# Altium schematic sheet B-MCU.SchDoc(B-MCU)
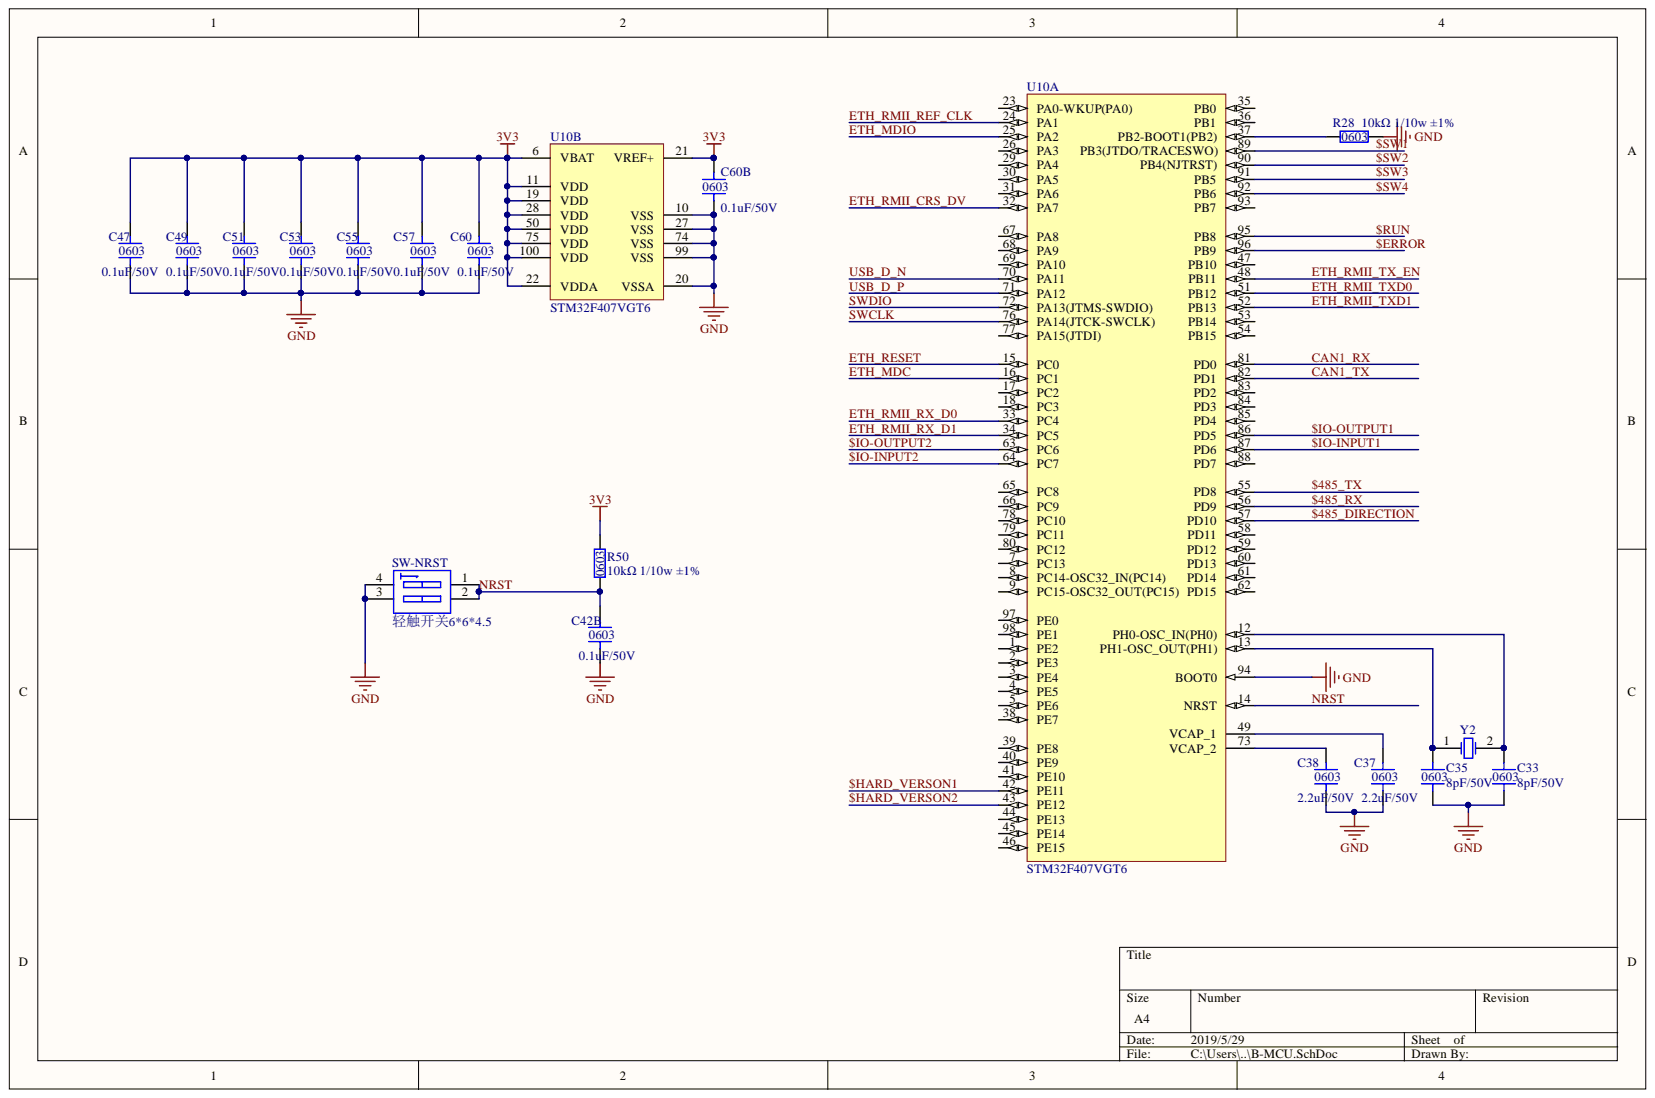
[diagram p0: B-MCU.SchDoc]

## Parts
C33  8pF/50V  [C-0603]
C35  8pF/50V  [C-0603]
C37  2.2uF/50V  [C-0603]
C38  2.2uF/50V  [C-0603]
C42B  0.1uF/50V  [C-0603]
C47  0.1uF/50V  [C-0603]
C49  0.1uF/50V  [C-0603]
C51  0.1uF/50V  [C-0603]
C53  0.1uF/50V  [C-0603]
C55  0.1uF/50V  [C-0603]
C57  0.1uF/50V  [C-0603]
C60  0.1uF/50V  [C-0603]
C60B  0.1uF/50V  [C-0603]
R28  10kΩ 1/10w ±1%  [R-0603]
R50  10kΩ 1/10w ±1%  [R-0603]
SW-NRST  轻触开关6*6*4.5  [轻触开关6*6*4.5]
U10  STM32F407VGT6  [STM-LQFP100_N]  (parts A,B)
Y2  FA-238 EPSON 3225 8PF 20ppm 25M  [3225XTAL]  (value not drawn)

## Nets
3V3: C47.2 C49.2 C51.2 C53.2 C55.2 C57.2 C60.2 C60B.2 R50.2 U10.6(VBAT) U10.11(VDD) U10.19(VDD) U10.21(VREF+) U10.22(VDDA) U10.28(VDD) U10.50(VDD) U10.75(VDD) U10.100(VDD)
GND: C33.1 C35.1 C37.1 C38.1 C42B.1 C47.1 C49.1 C51.1 C53.1 C55.1 C57.1 C60.1 C60B.1 R28.2 SW-NRST.3 SW-NRST.4 U10.10(VSS) U10.20(VSSA) U10.27(VSS) U10.74(VSS) U10.94(BOOT0) U10.99(VSS)
$485_DIRECTION: U10.57(PD10)
$485_RX: U10.56(PD9)
$485_TX: U10.55(PD8)
$ERROR: U10.96(PB9)
$HARD_VERSON1: U10.42(PE11)
$HARD_VERSON2: U10.43(PE12)
$IO-INPUT1: U10.87(PD6)
$IO-INPUT2: U10.64(PC7)
$IO-OUTPUT1: U10.86(PD5)
$IO-OUTPUT2: U10.63(PC6)
$RUN: U10.95(PB8)
$SW1: U10.89(PB3(JTDO/TRACESWO))
$SW2: U10.90(PB4(NJTRST))
$SW3: U10.91(PB5)
$SW4: U10.92(PB6)
CAN1_RX: U10.81(PD0)
CAN1_TX: U10.82(PD1)
ETH_MDC: U10.16(PC1)
ETH_MDIO: U10.25(PA2)
ETH_RESET: U10.15(PC0)
ETH_RMII_CRS_DV: U10.32(PA7)
ETH_RMII_REF_CLK: U10.24(PA1)
ETH_RMII_RX_D0: U10.33(PC4)
ETH_RMII_RX_D1: U10.34(PC5)
ETH_RMII_TX_EN: U10.48(PB11)
ETH_RMII_TXD0: U10.51(PB12)
ETH_RMII_TXD1: U10.52(PB13)
NRST: C42B.2 R50.1 SW-NRST.1 SW-NRST.2 U10.14(NRST)
SWCLK: U10.76(PA14(JTCK-SWCLK))
SWDIO: U10.72(PA13(JTMS-SWDIO))
USB_D_N: U10.70(PA11)
USB_D_P: U10.71(PA12)
NetC33_2: C33.2 U10.12(PH0-OSC_IN(PH0)) Y2.2
NetC35_2: C35.2 U10.13(PH1-OSC_OUT(PH1)) Y2.1
NetC37_2: C37.2 U10.49(VCAP_1)
NetC38_2: C38.2 U10.73(VCAP_2)
NetR28_1: R28.1 U10.37(PB2-BOOT1(PB2))

Not connected: U10 1(PE2) 2(PE3) 3(PE4) 4(PE5) 5(PE6) 7(PC13) 8(PC14-OSC32_IN(PC14)) 9(PC15-OSC32_OUT(PC15)) 17(PC2) 18(PC3) 23(PA0-WKUP(PA0)) 26(PA3) 29(PA4) 30(PA5) 31(PA6) 35(PB0) 36(PB1) 38(PE7) 39(PE8) 40(PE9) 41(PE10) 44(PE13) 45(PE14) 46(PE15) 47(PB10) 53(PB14) 54(PB15) 58(PD11) 59(PD12) 60(PD13) 61(PD14) 62(PD15) 65(PC8) 66(PC9) 67(PA8) 68(PA9) 69(PA10) 77(PA15(JTDI)) 78(PC10) 79(PC11) 80(PC12) 83(PD2) 84(PD3) 85(PD4) 88(PD7) 93(PB7) 97(PE0) 98(PE1)

## Library data of the parts
C33 C35 C37 C38 C42B C47 C49 C51 C53 C55 C57 C60 C60B = 10uF/50V: Component Kind: Standard; Library Name: dahuan.SchLib
R28 R50 = 2kΩ 1/10w ±1%: Component Kind: Standard; Library Name: dahuan.SchLib
SW-NRST = SW DIP-2: DIP Switch, 2 Position, SPST; Component Kind: Standard; LatestRevisionDate: 17-Jul-2002; LatestRevisionNote: Re-released for DXP Platform.; Library Name: Miscellaneous Devices.IntLib; PackageReference: DIP-4; Published: 24-Mar-1999; Publisher: Altium Limited
U10 = STM32F407VGT6: ARM Cortex-M4 32-bit MCU+FPU, 210 DMIPS, 1024 kB Flash, 192 kB Internal RAM, 82 I/Os, 100-pin LQFP, -40 to 85 degC, Tray; Manufacturer: STMicroelectronics; D/A Converter: 2x12-bit; FLASH Size (Prog) (kB): 1024; I/Os (High Current): 82; Internal RAM Size (kB): 192; Operating Frequency(F) (Processor speed) (MHz): 168; Supply Voltage(Vcc) max (V): 3.6; Supply Voltage(Vcc) min (V): 1.8; Component Kind: Standard; ComponentLink1Description: Manufacturer URL; ComponentLink1URL: http://www.st.com/web/en/home.html; ComponentLink2Description: Datasheet; ComponentLink2URL: http://www.st.com/st-web-ui/static/active/en/resource/technical/document/datasheet/DM00037051.pdf; ComponentLink3Description: Package Specification; ComponentLink3URL: http://www.st.com/st-web-ui/static/active/en/resource/technical/document/data_brief/DM00071938.pdf; Core: ARM Cortex-M4; DatasheetVersion: Rev. 4; Library Name: STMicroelectronics STM32 F4.IntLib; Mounting Technology: Surface Mount; PackageDescription: 100-Pin Low Profile Quad Flat Package, 14 x 14 mm Body, 0.5 mm Pitch; PackageReference: LQFP100; PackageVersion: Rev. 1, 06/2013; Packing: Tray; PartNumber: STM32F407VGT6; Supplier 1: Digi-Key; Supplier 2: Farnell; Supplier 3: Newark; Supplier 4: Mouser; Supplier Part Number 1: 497-11605-ND; Supplier Part Number 2: 2064368; Supplier Part Number 3: 73T2333; Supplier Part Number 4: 511-STM32F407VGT6
Y2 = XTAL: Crystal Oscillator; Component Kind: Standard; LatestRevisionDate: 17-Jul-2002; LatestRevisionNote: Re-released for DXP Platform.; Library Name: Miscellaneous Devices.IntLib; PackageDescription: Crystal, Thru-Hole; 2 Leads; Body 3.1 x 8.2 mm (Dia.xH); PackageReference: R38; Published: 8-Jun-2000; Simulation: XTAL; Publisher: Altium Limited
